# Altium schematic sheet MCU.SchDoc(MCU)
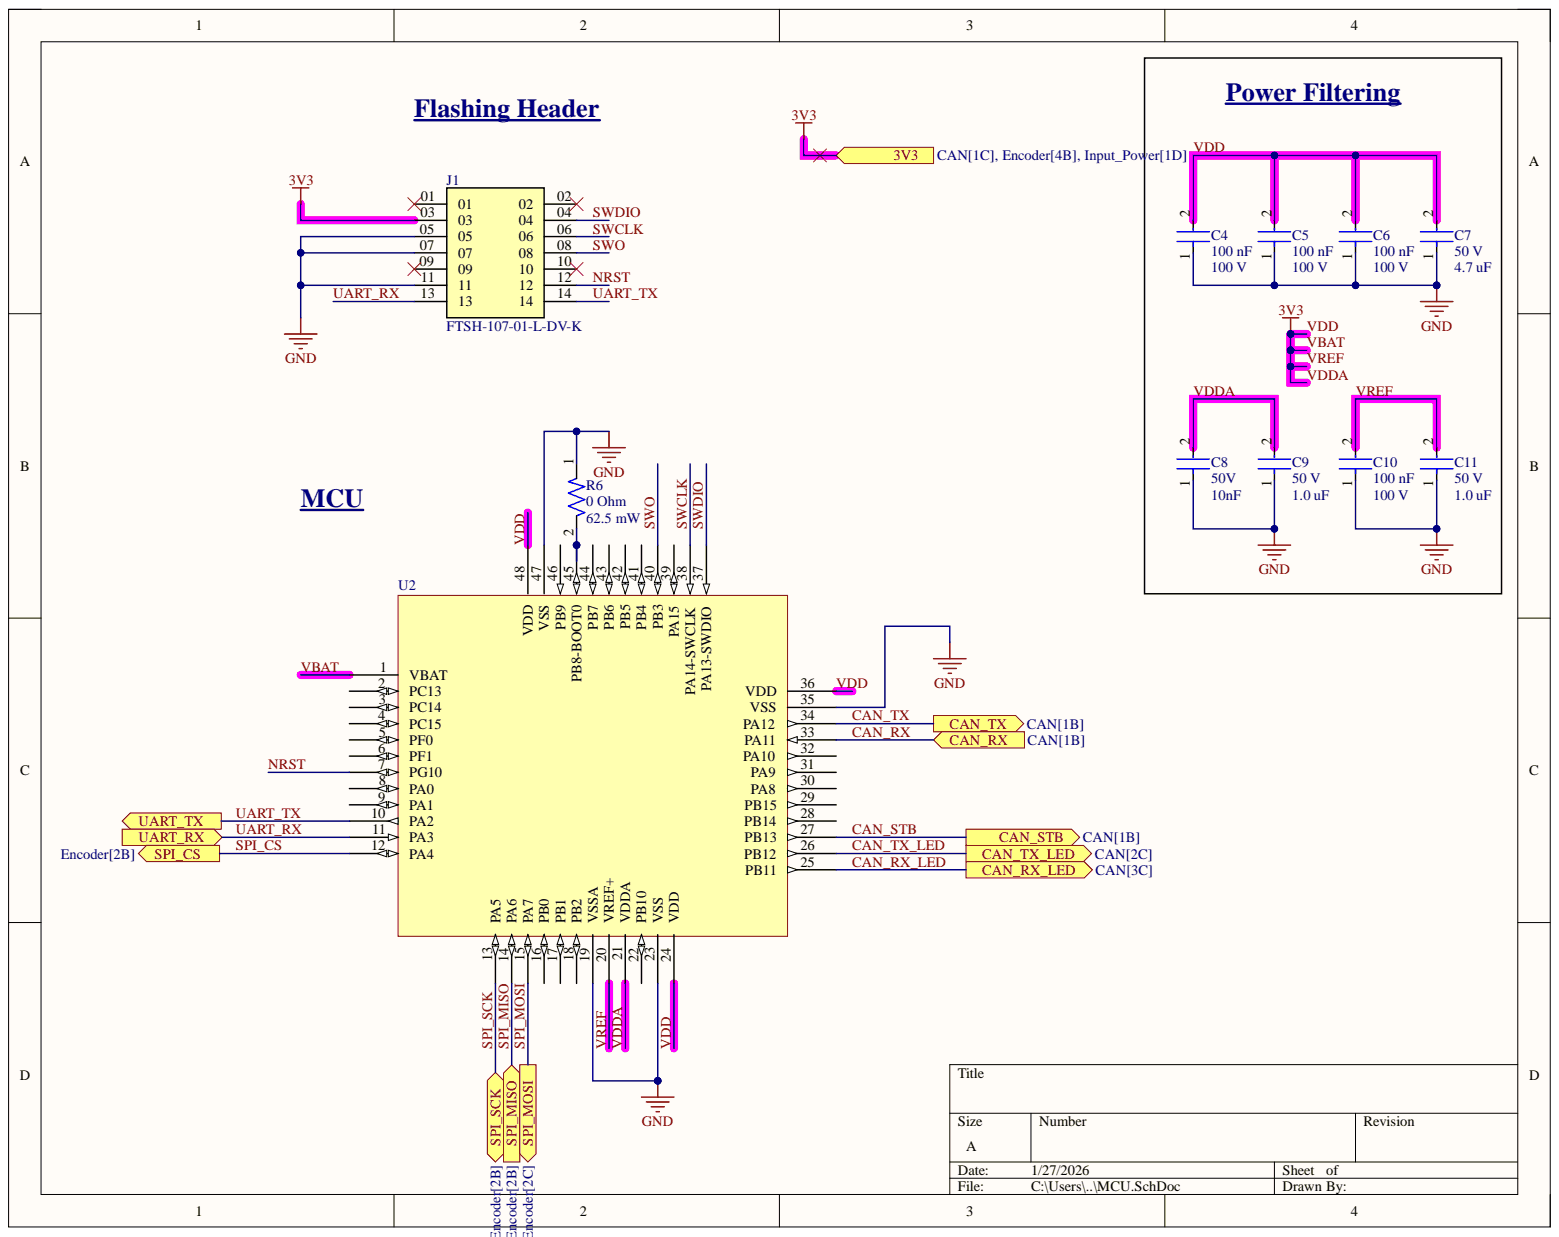
[diagram p0: MCU.SchDoc]

## Parts
C4  CC0603KRX7R0BB104  [CAP-0603]  (value not drawn)
C5  Capacitance: 100 nF  Voltage: 100 V  [CAP-0603]  (hidden comment: CC0603KRX7R0BB104)
C6  Capacitance: 100 nF  Voltage: 100 V  [CAP-0603]  (hidden comment: CC0603KRX7R0BB104)
C7  Capacitance: 4.7 uF  Voltage: 50 V  [CAP-0805]  (hidden comment: CC0805KKX5R9BB475)
C8  Capacitance: 10nF  Voltage: 50V  [CAP-0805]  (hidden comment: GRM2195C1H103JA01D)
C9  Voltage: 50 V  [CAP-0805]  (hidden comment: CC0805KKX7R9BB105)
C10  CC0603KRX7R0BB104  [CAP-0603]  (value not drawn)
C11  Capacitance: 1.0 uF  Voltage: 50 V  [CAP-0805]  (hidden comment: CC0805KKX7R9BB105)
J1  FTSH-107-01-L-DV-K  [SAMTEC_FTSH-107-01-L-DV-K]
R6  Resistance: 0 Ohm  [RES-0402]  (hidden comment: RC0402FR-070RL)
U2  STM32G431CBT6  [IC-LQFP48]  (value not drawn)

## Ports
3V3, CAN_RX, CAN_RX_LED, CAN_STB, CAN_TX, CAN_TX_LED, SPI_CS, SPI_MISO, SPI_MOSI, SPI_SCK, UART_RX, UART_TX

## Nets
GND: C4.1 C5.1 C6.1 C7.1 C8.1 C9.1 C10.1 C11.1 J1.05 J1.07 J1.11 R6.1 U2.19(VSSA) U2.23(VSS) U2.35(VSS) U2.47(VSS)
CAN_RX: U2.33(PA11) ; port CAN_RX
CAN_RX_LED: U2.25(PB11) ; port CAN_RX_LED
CAN_STB: U2.27(PB13) ; port CAN_STB
CAN_TX: U2.34(PA12) ; port CAN_TX
CAN_TX_LED: U2.26(PB12) ; port CAN_TX_LED
NRST: J1.12 U2.7(PG10)
SPI_CS: U2.12(PA4) ; port SPI_CS
SPI_MISO: U2.14(PA6) ; port SPI_MISO
SPI_MOSI: U2.15(PA7) ; port SPI_MOSI
SPI_SCK: U2.13(PA5) ; port SPI_SCK
SWCLK: J1.06 U2.38(PA14-SWCLK)
SWDIO: J1.04 U2.37(PA13-SWDIO)
SWO: J1.08 U2.40(PB3)
UART_RX: J1.13 U2.11(PA3) ; port UART_RX
UART_TX: J1.14 U2.10(PA2) ; port UART_TX
VBAT: C4.2 C5.2 C6.2 C7.2 C8.2 C9.2 C10.2 C11.2 J1.03 U2.1(VBAT) U2.20(VREF+) U2.21(VDDA) U2.24(VDD) U2.36(VDD) U2.48(VDD) ; port 3V3
NetR6_2: R6.2 U2.45(PB8-BOOT0)

Not connected: J1 01 02 09 10; U2 2(PC13) 3(PC14) 4(PC15) 5(PF0) 6(PF1) 8(PA0) 9(PA1) 16(PB0) 17(PB1) 18(PB2) 22(PB10) 28(PB14) 29(PB15) 30(PA8) 31(PA9) 32(PA10) 39(PA15) 41(PB4) 42(PB5) 43(PB6) 44(PB7) 46(PB9)

## Library data of the parts
C4 C10 = CAP-100N-0001: CAP CER 0.1UF 100V X7R 0603; Capacitance: 100 nF; Component Kind: Standard; ComponentLink1Description: Datasheet; ComponentLink1URL: https://www.yageo.com/upload/media/product/app/datasheet/mlcc/upy-np0x7r_mv_100-to-630v.pdf; Device Class: Ceramic; Library Name: JLC_25.SchLib; Size: 0603; Voltage: 100 V
C5 C6 = CAP-100N-0001: CAP CER 0.1UF 100V X7R 0603; Component Kind: Standard; ComponentLink1Description: Datasheet; ComponentLink1URL: https://www.yageo.com/upload/media/product/app/datasheet/mlcc/upy-np0x7r_mv_100-to-630v.pdf; Device Class: Ceramic; Library Name: JLC_25.SchLib; Size: 0603
C7 = CAP-4.7U-0002: CAP CER 4.7UF 50V X5R 0805; Component Kind: Standard; ComponentLink2Description: Datasheet; ComponentLink2URL: http://www.yageo.com/documents/recent/UPY-GPHC_Y5V_6.3V-to-50V_8.pdf; Device Class: Ceramic; Library Name: JLC_25.SchLib; Size: 0805
C8 = CAP-10N-0001: CAP CER 10000PF 50V C0G/NP0 0805; Component Kind: Standard; ComponentLink1Description: Datasheet; ComponentLink1URL: https://search.murata.co.jp/Ceramy/image/img/A01X/G101/ENG/GRM2195C1H103JA01-01.pdf; Device Class: Ceramic; Library Name: JLC_25.SchLib; Size: 0805
C9 = CAP-1U-0001: CAP CER 1UF 50V X7R 0805; Capacitance: 1.0 uF; Component Kind: Standard; ComponentLink2Description: Datasheet; ComponentLink2URL: https://www.yageo.com/upload/media/product/productsearch/datasheet/mlcc/UPY-GPHC_X7R_6.3V-to-250V_24.pdf; Device Class: Ceramic; Library Name: JLC_25.SchLib; Size: 0805
C11 = CAP-1U-0001: CAP CER 1UF 50V X7R 0805; Component Kind: Standard; ComponentLink2Description: Datasheet; ComponentLink2URL: https://www.yageo.com/upload/media/product/productsearch/datasheet/mlcc/UPY-GPHC_X7R_6.3V-to-250V_24.pdf; Device Class: Ceramic; Library Name: JLC_25.SchLib; Size: 0805
J1 = CONN_Flashing-0001: Connector Header Surface Mount 14 position 0.050" (1.27mm); MF: Samtec; Availability: Unavailable; Component Kind: Standard; ComponentLink1Description: Datasheet; ComponentLink1URL: https://mm.digikey.com/Volume0/opasdata/d220001/medias/docus/6209/ftsh-1xx-xx-xxx-dv-xxx-xxx-x-xx-mkt.pdf; Library Name: MasterLib.IntLib; MP: FTSH-107-01-L-DV-K; Package: None; Price: None
R6 = RES-0-0002-JLC: 62.5mW Thick Film Resistors 50V -55℃~+155℃ 0Ω 0402 Chip Resistor - Surface Mount ROHS; assembled?: yes; Component Kind: Standard; JLC part number: C106231; Library Name: MasterLib.IntLib; Power: 62.5 mW
U2 = IC-STM32G431CBT6: IC MCU 32BIT 128KB FLASH 48LQFP; Component Kind: Standard; ComponentLink1Description: Datasheet; ComponentLink1URL: https://www.st.com/resource/en/datasheet/stm32g431cb.pdf; Library Name: JLC_25.IntLib; Package: 48LQFP
